# Altium schematic sheet 02_RNWF UART to Cloud Add on Board .SchDoc("02_RNWF UART to Cloud Add on Board ") (continued)

## Library data of the parts
C1 = CAP0279: Manufacturer 1: KEMET; Manufacturer Part Number 1: C0402C104K8PACTU; Description_: CAP CER 0.1uF 10V 10% X5R SMD 0402; Dev Tools Status: Production; Populated: YES; Temperature Coefficient: X5R; Tolerance: 10%; Type: Ceramic; Category: Capacitor; Component Kind: Standard; ComponentLink1Description: SVN Datasheet; ComponentLink1URL: https://chn-vm-apps.microchip.com/mchprepo/parts/Datasheets/KEM_C1002_X7R_SMD.pdf; ComponentLink2Description: Local Datasheet; ComponentLink3Description: Open Symbol; ComponentLink4Description: Open Footprint; ComponentLink5Description: Open Footprint 2; ComponentLink6Description: Open Footprint 3; ComponentLink7Description: Open Footprint 4; Database Table Name: Capacitor; Library Name: MCHP-CDB.DbLib; Manufacturer 2: Murata; Manufacturer 3: KEMET; Manufacturer Part Number 2: GRM155R61A104KA01D; Manufacturer Part Number 3: C0402C104K8PAC; MCHP-ID: CAP0279; Operating Temperature: -55C to +85C; Quantity Override: 1; Revision: 1; Status: MCL Design; Supplier 1: Digi-Key; Supplier 2: Digi-Key; Supplier 3: KEMET; Supplier Part Number 1: 399-3027-1-ND; Supplier Part Number 2: 490-1318-2-ND; Supplier Part Number 3: C0402C104K8PAC; Symbol: Capacitor NP; ComponentLink2URL: C:\ALTIUM_WORK\00001_MCHP-CDB\Datasheets\KEM_C1002_X7R_SMD.pdf; ComponentLink3URL: C:\ALTIUM_WORK\00001_MCHP-CDB\Library\LibraryRefs\Capacitor NP.SchLib; ComponentLink4URL: C:\ALTIUM_WORK\00001_MCHP-CDB\Library\Footprints\CAP_C_0402.PcbLib
C2 C6 = CAP0279: Manufacturer 1: KEMET; Manufacturer Part Number 1: C0402C104K8PACTU; Description_: CAP CER 0.1uF 10V 10% X5R SMD 0402; Dev Tools Status: Production; Populated: YES; Temperature Coeffcient: X5R; Tolerance: 10%; Type: Ceramic; Category: Capacitor; Component Kind: Standard; ComponentLink1Description: SVN Datasheet; ComponentLink1URL: https://chn-vm-apps.microchip.com/mchprepo/parts/Datasheets/KEM_C1002_X7R_SMD.pdf; ComponentLink2Description: Local Datasheet; ComponentLink3Description: Open Symbol; ComponentLink4Description: Open Footprint; ComponentLink5Description: Open Footprint 2; ComponentLink6Description: Open Footprint 3; ComponentLink7Description: Open Footprint 4; Database Table Name: Capacitor; Library Name: MCHP-CDB.DbLib; Manufacturer 2: Murata; Manufacturer 3: KEMET; Manufacturer Part Number 2: GRM155R61A104KA01D; Manufacturer Part Number 3: C0402C104K8PAC; MCHP-ID: CAP0279; Operating Temperature: -55C to +85C; Quantity Override: 1; Revision: 1; Status: MCL Design; Supplier 1: Digi-Key; Supplier 2: Digi-Key; Supplier 3: KEMET; Supplier Part Number 1: 399-3027-1-ND; Supplier Part Number 2: 490-1318-2-ND; Supplier Part Number 3: C0402C104K8PAC; Symbol: Capacitor NP; ComponentLink2URL: C:\ALTIUM_WORK\00001_MCHP-CDB\Datasheets\KEM_C1002_X7R_SMD.pdf; ComponentLink3URL: C:\ALTIUM_WORK\00001_MCHP-CDB\Library\LibraryRefs\Capacitor NP.SchLib; ComponentLink4URL: C:\ALTIUM_WORK\00001_MCHP-CDB\Library\Footprints\CAP_C_0402.PcbLib
C3 C12 = CAP1268: Capacitor; Manufacturer 1: TDK Corporation; Manufacturer Part Number 1: C2012X7R1A475M125AC; Description_: CAP CER 4.7uF 10V 20% X7R SMD 0805; Dev Tools Status: Production; Owner: Mark Loper; Populated: YES; Temperature Coeffcient: X7R; Tolerance: 20%; Type: Ceramic; Category: Capacitor; Component Kind: Standard; ComponentLink1Description: SVN Datasheet; ComponentLink1URL: https://chn-vm-apps.microchip.com/mchprepo/parts/Datasheets/mlcc_commercial_general_en.pdf; ComponentLink2Description: Local Datasheet; ComponentLink3Description: Open Symbol; ComponentLink4Description: Open Footprint; ComponentLink5Description: Open Footprint 2; ComponentLink6Description: Open Footprint 3; ComponentLink7Description: Open Footprint 4; Database Table Name: Capacitor; Library Name: MCHP-CDB.DbLib; MCHP-ID: CAP1268; Operating Temperature: -55C to +125C; Quantity Override: 1; Revision: 1; Status: MCL Design; Supplier 1: Digi-Key; Supplier Part Number 1: 445-1605-1-ND; Symbol: Capacitor NP; ComponentLink2URL: C:\ALTIUM_WORK\00001_MCHP-CDB\Datasheets\mlcc_commercial_general_en.pdf; ComponentLink3URL: C:\ALTIUM_WORK\00001_MCHP-CDB\Library\LibraryRefs\Capacitor NP.SchLib; ComponentLink4URL: C:\ALTIUM_WORK\00001_MCHP-CDB\Library\Footprints\CAP_C_0805.PcbLib
C4 C5 C9 C17 C18 = CAP0279: Manufacturer 1: KEMET; Manufacturer Part Number 1: C0402C104K8PACTU; Description_: CAP CER 0.1uF 10V 10% X5R SMD 0402; Dev Tools Status: Production; Populated: YES; Restricted Sourcing: No; Temperature Coefficient: X5R; Tolerance: 10%; Type: Ceramic; Category: Capacitor; Component Kind: Standard; ComponentLink1Description: SVN Datasheet; ComponentLink1URL: https://chn-vm-apps.microchip.com/mchprepo/parts/Datasheets/KEM_C1002_X7R_SMD.pdf; ComponentLink2Description: Local Datasheet; ComponentLink3Description: Open Symbol; ComponentLink4Description: Open Footprint; ComponentLink5Description: Open Footprint 2; ComponentLink6Description: Open Footprint 3; ComponentLink7Description: Open Footprint 4; Database Table Name: Capacitor; Library Name: MCHP-CDB.DbLib; Manufacturer 2: Murata; Manufacturer 3: KEMET; Manufacturer Part Number 2: GRM155R61A104KA01D; Manufacturer Part Number 3: C0402C104K8PAC; MCHP-ID: CAP0279; Operating Temperature: -55C to +85C; Quantity Override: 1; Revision: 1; Status: MCL Design; Supplier 1: Digi-Key; Supplier 2: Digi-Key; Supplier 3: KEMET; Supplier Part Number 1: 399-3027-1-ND; Supplier Part Number 2: 490-1318-2-ND; Supplier Part Number 3: C0402C104K8PAC; Symbol: Capacitor NP; ComponentLink2URL: C:\ALTIUM_WORK\00001_MCHP-CDB\Datasheets\KEM_C1002_X7R_SMD.pdf; ComponentLink3URL: C:\ALTIUM_WORK\00001_MCHP-CDB\Library\LibraryRefs\Capacitor NP.SchLib; ComponentLink4URL: C:\ALTIUM_WORK\00001_MCHP-CDB\Library\Footprints\CAP_C_0402.PcbLib
C7 = CAP0597: Manufacturer 1: TDK Corporation; Manufacturer Part Number 1: C1608X5R1C225K080AB; Description_: CAP CER 2.2uF 16V 10% X5R SMD 0603; Dev Tools Status: Production; Populated: YES; Temperature Coefficient: X5R; Tolerance: 10%; Type: Ceramic; Voltage: 16V; Category: Capacitor; Component Kind: Standard; ComponentLink1Description: SVN Datasheet; ComponentLink1URL: https://chn-vm-apps.microchip.com/mchprepo/parts/Datasheets/general_B11.pdf; ComponentLink2Description: Local Datasheet; ComponentLink3Description: Open Symbol; ComponentLink4Description: Open Footprint; ComponentLink5Description: Open Footprint 2; ComponentLink6Description: Open Footprint 3; ComponentLink7Description: Open Footprint 4; Database Table Name: Capacitor; Library Name: MCHP-CDB.DbLib; MCHP-ID: CAP0597; Operating Temperature: -55C to +85C; Package: 0603; Quantity Override: 1; Revision: 6; Status: MCL Design; Supplier 1: Digi-Key; Supplier Part Number 1: 445-5157-1-ND; Symbol: Capacitor NP; ComponentLink2URL: C:\ALTIUM_WORK\00001_MCHP-CDB\Datasheets\general_B11.pdf; ComponentLink3URL: C:\ALTIUM_WORK\00001_MCHP-CDB\Library\LibraryRefs\Capacitor NP.SchLib; ComponentLink4URL: C:\ALTIUM_WORK\00001_MCHP-CDB\Library\Footprints\CAP_C_0603.PcbLib
C8 = CAP0279: Manufacturer 1: KEMET; Manufacturer Part Number 1: C0402C104K8PACTU; Description_: CAP CER 0.1uF 10V 10% X5R SMD 0402; Dev Tools Status: Production; Temperature Coeffcient: X5R; Tolerance: 10%; Type: Ceramic; Category: Capacitor; Component Kind: Standard; ComponentLink1Description: SVN Datasheet; ComponentLink1URL: https://chn-vm-apps.microchip.com/mchprepo/parts/Datasheets/KEM_C1002_X7R_SMD.pdf; ComponentLink2Description: Local Datasheet; ComponentLink3Description: Open Symbol; ComponentLink4Description: Open Footprint; ComponentLink5Description: Open Footprint 2; ComponentLink6Description: Open Footprint 3; ComponentLink7Description: Open Footprint 4; Database Table Name: Capacitor; Library Name: MCHP-CDB.DbLib; Manufacturer 2: Murata; Manufacturer 3: KEMET; Manufacturer Part Number 2: GRM155R61A104KA01D; Manufacturer Part Number 3: C0402C104K8PAC; MCHP-ID: CAP0279; Operating Temperature: -55C to +85C; Quantity Override: 1; Revision: 1; Status: MCL Design; Supplier 1: Digi-Key; Supplier 2: Digi-Key; Supplier 3: KEMET; Supplier Part Number 1: 399-3027-1-ND; Supplier Part Number 2: 490-1318-2-ND; Supplier Part Number 3: C0402C104K8PAC; Symbol: Capacitor NP; ComponentLink2URL: C:\ALTIUM_WORK\00001_MCHP-CDB\Datasheets\KEM_C1002_X7R_SMD.pdf; ComponentLink3URL: C:\ALTIUM_WORK\00001_MCHP-CDB\Library\LibraryRefs\Capacitor NP.SchLib; ComponentLink4URL: C:\ALTIUM_WORK\00001_MCHP-CDB\Library\Footprints\CAP_C_0402.PcbLib
C10 = CAP0627: Manufacturer 1: TDK; Manufacturer Part Number 1: C2012X5R1C226K125AC; Description_: CAP CER 22uF 16V 10% X5R SMD 0805; Dev Tools Status: Production; Populated: YES; Temperature Coeffcient: X5R; Tolerance: 10%; Type: Ceramic; Category: Capacitor; Component Kind: Standard; ComponentLink1Description: SVN Datasheet; ComponentLink1URL: https://chn-vm-apps.microchip.com/mchprepo/parts/Datasheets/general_B11.pdf; ComponentLink2Description: Local Datasheet; ComponentLink3Description: Open Symbol; ComponentLink4Description: Open Footprint; ComponentLink5Description: Open Footprint 2; ComponentLink6Description: Open Footprint 3; ComponentLink7Description: Open Footprint 4; Database Table Name: Capacitor; Library Name: MCHP-CDB.DbLib; MCHP-ID: CAP0627; Operating Temperature: -55C to +85C; Quantity Override: 1; Revision: 1; Status: MCL Design; Supplier 1: Digi-Key; Supplier Part Number 1: 445-6797-2-ND; Symbol: Capacitor NP; ComponentLink2URL: C:\ALTIUM_WORK\00001_MCHP-CDB\Datasheets\general_B11.pdf; ComponentLink3URL: C:\ALTIUM_WORK\00001_MCHP-CDB\Library\LibraryRefs\Capacitor NP.SchLib; ComponentLink4URL: C:\ALTIUM_WORK\00001_MCHP-CDB\Library\Footprints\CAP_C_0805.PcbLib
C11 = CAP1201: Manufacturer 1: Murata; Manufacturer Part Number 1: GRM033R61A103KA01D; Description_: CAP CER 10000pF 10V 10% X5R SMD 0201; Dev Tools Status: Production; Owner: Tad Ahlvers; Populated: YES; Restricted Sourcing: No; Temperature Coefficient: X5R; Tolerance: 10%; Type: Ceramic; Category: Capacitor; Component Kind: Standard; ComponentLink1Description: SVN Datasheet; ComponentLink1URL: https://chn-vm-apps.microchip.com/mchprepo/parts/Datasheets/c02e.pdf; ComponentLink2Description: Local Datasheet; ComponentLink3Description: Open Symbol; ComponentLink4Description: Open Footprint; ComponentLink5Description: Open Footprint 2; ComponentLink6Description: Open Footprint 3; ComponentLink7Description: Open Footprint 4; Database Table Name: Capacitor; Library Name: MCHP-CDB.DbLib; MCHP-ID: CAP1201; Operating Temperature: -55C to +85C; Quantity Override: 1; Revision: 1; Status: MCL Design; Supplier 1: Digi-Key; Supplier Part Number 1: 490-3166-2-ND; Symbol: Capacitor NP; ComponentLink2URL: C:\ALTIUM_WORK\00001_MCHP-CDB\Datasheets\c02e.pdf; ComponentLink3URL: C:\ALTIUM_WORK\00001_MCHP-CDB\Library\LibraryRefs\Capacitor NP.SchLib; ComponentLink4URL: C:\ALTIUM_WORK\00001_MCHP-CDB\Library\Footprints\CAP_C_0201.PcbLib
C13 C14 = CAP1237: Capacitor; Manufacturer 1: Murata; Manufacturer Part Number 1: GRM1555C1H180GA01D; Description_: CAP CER 18pF 50V 2% NP0 SMD 0402; Dev Tools Status: Production; Owner: Tad Ahlvers; Populated: YES; Temperature Coeffcient: 30ppm/C; Tolerance: 5%; Type: Ceramic; Voltage: 50V; Category: Capacitor; Component Kind: Standard; ComponentLink1Description: SVN Datasheet; ComponentLink1URL: https://chn-vm-apps.microchip.com/mchprepo/parts/Datasheets/GRM1555C1H180GA01D#.pdf; ComponentLink2Description: Local Datasheet; ComponentLink3Description: Open Symbol; ComponentLink4Description: Open Footprint; ComponentLink5Description: Open Footprint 2; ComponentLink6Description: Open Footprint 3; ComponentLink7Description: Open Footprint 4; Database Table Name: Capacitor; Library Name: MCHP-CDB.DbLib; MCHP-ID: CAP1237; Operating Temperature: -55C to +125C; Package: 0402; Quantity Override: 1; Revision: 2; Status: MCL Design; Supplier 1: Digi-Key; Supplier Part Number 1: 490-6204-6-ND; Symbol: Capacitor NP; ComponentLink2URL: C:\ALTIUM_WORK\00001_MCHP-CDB\Datasheets\GRM1555C1H180GA01D#.pdf; ComponentLink3URL: C:\ALTIUM_WORK\00001_MCHP-CDB\Library\LibraryRefs\Capacitor NP.SchLib; ComponentLink4URL: C:\ALTIUM_WORK\00001_MCHP-CDB\Library\Footprints\CAP_C_0402.PcbLib
C15 C16 = CAP0279: Manufacturer 1: KEMET; Manufacturer Part Number 1: C0402C104K8PACTU; Description_: CAP CER 0.1uF 10V 10% X5R SMD 0402; Dev Tools Status: Production; Restricted Sourcing: No; Temperature Coefficient: X5R; Tolerance: 10%; Type: Ceramic; Category: Capacitor; Component Kind: Standard; ComponentLink1Description: SVN Datasheet; ComponentLink1URL: https://chn-vm-apps.microchip.com/mchprepo/parts/Datasheets/KEM_C1002_X7R_SMD.pdf; ComponentLink2Description: Local Datasheet; ComponentLink3Description: Open Symbol; ComponentLink4Description: Open Footprint; ComponentLink5Description: Open Footprint 2; ComponentLink6Description: Open Footprint 3; ComponentLink7Description: Open Footprint 4; Database Table Name: Capacitor; Library Name: MCHP-CDB.DbLib; Manufacturer 2: Murata; Manufacturer 3: KEMET; Manufacturer Part Number 2: GRM155R61A104KA01D; Manufacturer Part Number 3: C0402C104K8PAC; MCHP-ID: CAP0279; Operating Temperature: -55C to +85C; Package: 0402; Quantity Override: 1; Revision: 1; Status: MCL Design; Supplier 1: Digi-Key; Supplier 2: Digi-Key; Supplier 3: KEMET; Supplier Part Number 1: 399-3027-1-ND; Supplier Part Number 2: 490-1318-2-ND; Supplier Part Number 3: C0402C104K8PAC; Symbol: Capacitor NP; ComponentLink2URL: C:\ALTIUM_WORK\00001_MCHP-CDB\Datasheets\KEM_C1002_X7R_SMD.pdf; ComponentLink3URL: C:\ALTIUM_WORK\00001_MCHP-CDB\Library\LibraryRefs\Capacitor NP.SchLib; ComponentLink4URL: C:\ALTIUM_WORK\00001_MCHP-CDB\Library\Footprints\CAP_C_0402.PcbLib
C19 = CAP1684: Manufacturer 1: TDK Corporation; Manufacturer Part Number 1: C1005X5R1A475K050BC; Description_: CAP CER 4.7uF 10V 10% X5R SMD 0402; Dev Tools Status: Production; Owner: Jesus Aviles; Temperature Coeffcient: X5R; Tolerance: 10%; Type: Ceramic; Category: Capacitor; Component Kind: Standard; ComponentLink1Description: SVN Datasheet; ComponentLink2Description: Local Datasheet; ComponentLink3Description: Open Symbol; ComponentLink4Description: Open Footprint; ComponentLink5Description: Open Footprint 2; ComponentLink6Description: Open Footprint 3; ComponentLink7Description: Open Footprint 4; Database Table Name: Capacitor; Library Name: MCHP-CDB.DbLib; MCHP-ID: CAP1684; Operating Temperature: -55C to 85C; Quantity Override: 1; Revision: 1; Status: MCL Design; Supplier 1: Digi-Key; Supplier Part Number 1: 445-13820-1-ND; Symbol: Capacitor NP; ComponentLink3URL: C:\ALTIUM_WORK\00001_MCHP-CDB\Library\LibraryRefs\Capacitor NP.SchLib; ComponentLink4URL: C:\ALTIUM_WORK\00001_MCHP-CDB\Library\Footprints\CAP_C_0402.PcbLib
D1-D3 = DIODE1091: Manufacturer 1: NXP Semiconductors; Manufacturer Part Number 1: PESD5V0X1BL,315; Description_: DIO ZENER PESD5V0X1BL 5V SMD SOD-882; Dev Tools Status: Production; Owner: Jairuz Agang-ang; Reverse Current: 100nA; Category: Diode; Component Kind: Standard; ComponentLink1Description: SVN Datasheet; ComponentLink1URL: https://chn-vm-apps.microchip.com/mchprepo/parts/Datasheets/PESD5V0X1BL.pdf; ComponentLink2Description: Local Datasheet; ComponentLink3Description: Open Symbol; ComponentLink4Description: Open Footprint; ComponentLink5Description: Open Footprint 2; ComponentLink6Description: Open Footprint 3; ComponentLink7Description: Open Footprint 4; Database Table Name: Diode; Library Name: MCHP-CDB.DbLib; MCHP-ID: DIODE1091; Operating Temperature: -55C to 150C; Package: SOD-882; Quantity Override: 1; Revision: 1; Status: MCL Design; Supplier 1: Digi-Key; Supplier 2: Digi-Key; Supplier Part Number 1: 568-4674-1-ND; Supplier Part Number 2: 568-4674-2-ND; Symbol: Diode TVS Bidirectional; Type: TVS; ComponentLink2URL: C:\ALTIUM_WORK\00001_MCHP-CDB\Datasheets\PESD5V0X1BL.pdf; ComponentLink3URL: C:\ALTIUM_WORK\00001_MCHP-CDB\Library\LibraryRefs\Diode TVS Bidirectional.SchLib; ComponentLink4URL: C:\ALTIUM_WORK\00001_MCHP-CDB\Library\Footprints\SOD-882.PcbLib
D4 = DIODE1058: LED; Manufacturer 1: Lite-On; Manufacturer Part Number 1: LTST-C190EKT; Database Table Name: Diode; Description_: DIO LED RED 2V 30mA 2mcd Clear SMD 0603; Dev Tools Status: Production; Forward Current: 30mA; Owner: Tad Ahlvers; Populated: YES; Power: 100mW; Reverse Current: 100uA; Reverse Voltage: 5V; Type: LED Single; Category: Diode; Component Kind: Standard; ComponentLink1Description: SVN Datasheet; ComponentLink1URL: https://chn-vm-apps.microchip.com/mchprepo/parts/Datasheets/LTST-C190EKT.pdf; ComponentLink2Description: Local Datasheet; ComponentLink3Description: Open Symbol; ComponentLink4Description: Open Footprint; ComponentLink5Description: Open Footprint 2; ComponentLink6Description: Open Footprint 3; ComponentLink7Description: Open Footprint 4; Library Name: MCHP-CDB.DbLib; Manufacturer 2: ZhiDing; Manufacturer Part Number 2: AL-S0603SRC-50-08-2; MCHP-ID: DIODE1058; Operating Temperature: -55C to +85C; Package: 0603; Quantity Override: 1; Revision: 2; Status: MCL Design; Supplier 1: Digi-Key; Supplier 2: Raynen; Supplier Part Number 1: 160-1182-1-ND; Supplier Part Number 2: AL-S0603SRC-50-08-2; Symbol: LED_red; ComponentLink2URL: C:\ALTIUM_WORK\00001_MCHP-CDB\Datasheets\LTST-C190EKT.pdf; ComponentLink3URL: C:\ALTIUM_WORK\00001_MCHP-CDB\Library\LibraryRefs\LED_red.SchLib; ComponentLink4URL: C:\ALTIUM_WORK\00001_MCHP-CDB\Library\Footprints\LED_0603_RED.PcbLib
FB1 = IND1092: FERRITE 180R 1.5A SMD 0603; Manufacturer 1: Murata Electronics North America; Manufacturer Part Number 1: BLM21PG221SN1D; Database Table Name: Inductor; DCR: 0.045R; Description_: FERRITE 2A 220R SMD 0805; Dev Tools Status: Production; Owner: Stephen Humberd; Test Frequency: 100MHz; Category: Inductor; Component Kind: Standard; ComponentLink1Description: SVN Datasheet; ComponentLink1URL: https://chn-vm-apps.microchip.com/mchprepo/parts/Datasheets/L0110S0100BLM21P.pdf; ComponentLink2Description: Local Datasheet; ComponentLink3Description: Open Symbol; ComponentLink4Description: Open Footprint; ComponentLink5Description: Open Footprint 2; ComponentLink6Description: Open Footprint 3; ComponentLink7Description: Open Footprint 4; Library Name: MCHP-CDB.DbLib; MCHP-ID: IND1092; Operating Temperature: -55C to +125C; Package: 0805; Quantity Override: 1; Revision: B; Status: MCL Design; Supplier 1: Digi-Key; Supplier Part Number 1: 490-1054-1-ND; Symbol: Ferrite Bead; Type: Ferrite Bead; ComponentLink2URL: C:\ALTIUM_WORK\00001_MCHP-CDB\Datasheets\L0110S0100BLM21P.pdf; ComponentLink3URL: C:\ALTIUM_WORK\00001_MCHP-CDB\Library\LibraryRefs\Ferrite Bead.SchLib; ComponentLink4URL: C:\ALTIUM_WORK\00001_MCHP-CDB\Library\Footprints\IND_C_0805.PcbLib
FB2 FB3 = IND1735: Manufacturer 1: Murata Electronics; Manufacturer Part Number 1: BLM15AG121SN1D; DCR: 0.19R; Description_: FERRITE 120R @ 100MHz 0.55A 0.19R SMD 0402; Dev Tools Status: Design; Owner: Jojie Batislaon; Populated: YES; RMS Current: 0.55A; Test Frequency: 100MHz; Type: Ferrite Bead; Category: Inductor; Component Kind: Standard; ComponentLink1Description: SVN Datasheet; ComponentLink1URL: https://chn-vm-apps.microchip.com/mchprepo/parts/Datasheets/ENFA0018.pdf; ComponentLink2Description: Local Datasheet; ComponentLink3Description: Open Symbol; ComponentLink4Description: Open Footprint; ComponentLink5Description: Open Footprint 2; ComponentLink6Description: Open Footprint 3; ComponentLink7Description: Open Footprint 4; Database Table Name: Inductor; Description: Inductor; Library Name: MCHP-CDB.DbLib; MCHP-ID: IND1735; Operating Temperature: -55C to +125C; Package: 0402; Quantity Override: 1; Revision: 1; Status: MCL Design; Supplier 1: Digi-Key; Supplier Part Number 1: 490-1005-2-ND; Symbol: Inductor; ComponentLink2URL: C:\ALTIUM_WORK\00001_MCHP-CDB\Datasheets\ENFA0018.pdf; ComponentLink3URL: C:\ALTIUM_WORK\00001_MCHP-CDB\Library\LibraryRefs\Inductor.SchLib; ComponentLink4URL: C:\ALTIUM_WORK\00001_MCHP-CDB\Library\Footprints\IND_C_0402.PcbLib
J1 = CON2554: USB type C connector; Manufacturer 1: GCT; Manufacturer Part Number 1: USB4105-GF-A; Current: 5A; Description_: CONN USB2.0 TYPE-C Female SMD/TH R/A; Dev Tools Status: Design; Owner: Balaji Narayana; Populated: YES; Voltage: 20V; Assembly Type: SMD; Category: Connector; Component Kind: Standard; ComponentLink1Description: SVN Datasheet; ComponentLink1URL: https://chn-vm-apps.microchip.com/mchprepo/parts/Datasheets/USB4105-GF-A.pdf; ComponentLink2Description: Local Datasheet; ComponentLink3Description: Open Symbol; ComponentLink4Description: Open Footprint; ComponentLink5Description: Open Footprint 2; ComponentLink6Description: Open Footprint 3; ComponentLink7Description: Open Footprint 4; Database Table Name: Connector; Library Name: MCHP-CDB.DbLib; Manufacturer 2: Cvilux USA; Manufacturer Part Number 2: CU3216SASDLR010-NH; MCHP-ID: CON2554; Operating Temperature: -40C to 85C; Quantity Override: 1; Revision: 1; Status: MCL Design; Supplier 1: Digi-Key; Supplier 2: Digi-Key; Supplier Part Number 1: 2073-USB4105-GF-ATR-ND; Supplier Part Number 2: 2987-CU3216SASDLR010-NHTR-ND; Symbol: CON_USB-2.0_TYPE-C_RA; Type: USB; ComponentLink2URL: C:\ALTIUM_WORK\00001_MCHP-CDB\Datasheets\USB4105-GF-A.pdf; ComponentLink3URL: C:\ALTIUM_WORK\00001_MCHP-CDB\Library\LibraryRefs\CON_USB-2.0_TYPE-C_RA.SchLib; ComponentLink4URL: C:\ALTIUM_WORK\00001_MCHP-CDB\Library\Footprints\CON_USB-2.0_TYPE-C_USB4105_SMD.PcbLib
J2 = CON1388: Manufacturer 1: Sullins; Manufacturer Part Number 1: GRPB081VWVN-RC; Database Table Name: Connector; Description_: CON HDR-1.27 Male 1x8 Gold 3MH TH VERT; Dev Tools Status: Production; Owner: Mike Ragsdale; Restricted Sourcing: No; Category: Connector; Component Kind: Standard; ComponentLink1Description: SVN Datasheet; ComponentLink1URL: https://chn-vm-apps.microchip.com/mchprepo/parts/Datasheets/71_GRPB___1VWVN-RC__10957-C.pdf; ComponentLink2Description: Local Datasheet; ComponentLink3Description: Open Symbol; ComponentLink4Description: Open Footprint; ComponentLink5Description: Open Footprint 2; ComponentLink6Description: Open Footprint 3; ComponentLink7Description: Open Footprint 4; Description: Header 1x8; Library Name: MCHP-CDB.DbLib; MCHP-ID: CON1388; Quantity Override: 1; Revision: 1; Status: MCL Design; Supplier 1: Digi-Key; Supplier Part Number 1: S9014E-08-ND; Symbol: Header 1x8; Type: Connector Strip; ComponentLink2URL: C:\ALTIUM_WORK\00001_MCHP-CDB\Datasheets\71_GRPB___1VWVN-RC__10957-C.pdf; ComponentLink3URL: C:\ALTIUM_WORK\00001_MCHP-CDB\Library\LibraryRefs\Header 1x8.SchLib; ComponentLink4URL: C:\ALTIUM_WORK\00001_MCHP-CDB\Library\Footprints\HDR_M_1.27_1x8.PcbLib
J3 = CON0441: Manufacturer 1: Sullins; Manufacturer Part Number 1: PEC05DAAN; Current: 3A; Database Table Name: Connector; Description_: CON HDR-2.54 Male 2x5 Tin 5.84MH TH VERT; Dev Tools Status: Production; Owner: Mike Sullivan (Arena); Populated: YES; Restricted Sourcing: No; Category: Connector; Component Kind: Standard; ComponentLink1Description: SVN Datasheet; ComponentLink1URL: https://chn-vm-apps.microchip.com/mchprepo/parts/Datasheets/97_Page78-79_HEADER_.100_2.54mm_MALE_BREAKAWAY.pdf; ComponentLink2Description: Local Datasheet; ComponentLink3Description: Open Symbol; ComponentLink4Description: Open Footprint; ComponentLink5Description: Open Footprint 2; ComponentLink6Description: Open Footprint 3; ComponentLink7Description: Open Footprint 4; Library Name: MCHP-CDB.DbLib; Manufacturer 2: SHENZHEN JUNHAO ENGINEERING; Manufacturer Part Number 2: R-213-1011-0021-400; MCHP-ID: CON0441; Quantity Override: 1; Revision: 2; Status: MCL Design; Supplier 1: Digi-Key; Supplier 2: SHENZHEN JUNHAO ENGINEERING; Supplier Part Number 1: S2012E-05-ND; Supplier Part Number 2: R-213-1011-0021-400; Symbol: Header 2x5; Type: Header 2.54mm; ComponentLink2URL: C:\ALTIUM_WORK\00001_MCHP-CDB\Datasheets\97_Page78-79_HEADER_.100_2.54mm_MALE_BREAKAWAY.pdf; ComponentLink3URL: C:\ALTIUM_WORK\00001_MCHP-CDB\Library\LibraryRefs\Header 2x5.SchLib; ComponentLink4URL: C:\ALTIUM_WORK\00001_MCHP-CDB\Library\Footprints\HDR_M_2.54_2x5.PcbLib
J4 = CON1236: Manufacturer 1: Molex Inc; Manufacturer Part Number 1: 0901200122; Database Table Name: Connector; Description_: CON HDR-2.54 Male 1x2 Tin 6.75MH TH VERT; Dev Tools Status: Production; Owner: Jairuz Agang-ang; Restricted Sourcing: No; Value: HDR-2.54 Male 1x2; Category: Connector; Component Kind: Standard; ComponentLink1Description: SVN Datasheet; ComponentLink1URL: https://chn-vm-apps.microchip.com/mchprepo/parts/Datasheets/901200122_sd.pdf; ComponentLink2Description: Local Datasheet; ComponentLink3Description: Open Symbol; ComponentLink4Description: Open Footprint; ComponentLink5Description: Open Footprint 2; ComponentLink6Description: Open Footprint 3; ComponentLink7Description: Open Footprint 4; Library Name: MCHP-CDB.DbLib; Manufacturer 2: SHENZHEN JUNHAO ENGINEERING; Manufacturer Part Number 2: R-211-0211-0021-000; MCHP-ID: CON1236; Quantity Override: 1; Revision: 2; Status: MCL Design; Supplier 1: Digi-Key; Supplier 2: SHENZHEN JUNHAO ENGINEERING; Supplier Part Number 1: WM8072-ND; Supplier Part Number 2: R-211-0211-0021-000; Symbol: Header 1x2; Type: Header 2.54mm; ComponentLink2URL: C:\ALTIUM_WORK\00001_MCHP-CDB\Datasheets\901200122_sd.pdf; ComponentLink3URL: C:\ALTIUM_WORK\00001_MCHP-CDB\Library\LibraryRefs\Header 1x2.SchLib; ComponentLink4URL: C:\ALTIUM_WORK\00001_MCHP-CDB\Library\Footprints\HDR_M_2.54_1x2.PcbLib
J5 = CON1105: Manufacturer 1: FCI; Manufacturer Part Number 1: 68000-103HLF; Database Table Name: Connector; Description_: CON HDR-2.54 Male 1x3 Gold 5.84MH TH VERT; Dev Tools Status: Production; Owner: Bogdan P (M91398); Populated: YES; Value: HDR-2.54 Male 1x3; Category: Connector; Component Kind: Standard; ComponentLink1Description: SVN Datasheet; ComponentLink1URL: https://chn-vm-apps.microchip.com/mchprepo/parts/Datasheets/68000.pdf; ComponentLink2Description: Local Datasheet; ComponentLink3Description: Open Symbol; ComponentLink4Description: Open Footprint; ComponentLink5Description: Open Footprint 2; ComponentLink6Description: Open Footprint 3; ComponentLink7Description: Open Footprint 4; Library Name: MCHP-CDB.DbLib; MCHP-ID: CON1105; Quantity Override: 1; Revision: 0; Status: MCL Design; Supplier 1: Digi-Key; Supplier Part Number 1: 609-3461-ND; Symbol: Header 1x3; Type: Header 2.54mm; ComponentLink2URL: C:\ALTIUM_WORK\00001_MCHP-CDB\Datasheets\68000.pdf; ComponentLink3URL: C:\ALTIUM_WORK\00001_MCHP-CDB\Library\LibraryRefs\Header 1x3.SchLib; ComponentLink4URL: C:\ALTIUM_WORK\00001_MCHP-CDB\Library\Footprints\HDR_M_2.54_1x3.PcbLib
J6 = CON1236: Manufacturer 1: Molex Inc; Manufacturer Part Number 1: 0901200122; Database Table Name: Connector; Description_: CON HDR-2.54 Male 1x2 Tin 6.75MH TH VERT; Dev Tools Status: Production; Owner: Jairuz Agang-ang; Populated: YES; Restricted Sourcing: No; Category: Connector; Component Kind: Standard; ComponentLink1Description: SVN Datasheet; ComponentLink1URL: https://chn-vm-apps.microchip.com/mchprepo/parts/Datasheets/901200122_sd.pdf; ComponentLink2Description: Local Datasheet; ComponentLink3Description: Open Symbol; ComponentLink4Description: Open Footprint; ComponentLink5Description: Open Footprint 2; ComponentLink6Description: Open Footprint 3; ComponentLink7Description: Open Footprint 4; Library Name: MCHP-CDB.DbLib; Manufacturer 2: SHENZHEN JUNHAO ENGINEERING; Manufacturer Part Number 2: R-211-0211-0021-000; MCHP-ID: CON1236; Quantity Override: 1; Revision: 2; Status: MCL Design; Supplier 1: Digi-Key; Supplier 2: SHENZHEN JUNHAO ENGINEERING; Supplier Part Number 1: WM8072-ND; Supplier Part Number 2: R-211-0211-0021-000; Symbol: Header 1x2; Type: Header 2.54mm; ComponentLink2URL: C:\ALTIUM_WORK\00001_MCHP-CDB\Datasheets\901200122_sd.pdf; ComponentLink3URL: C:\ALTIUM_WORK\00001_MCHP-CDB\Library\LibraryRefs\Header 1x2.SchLib; ComponentLink4URL: C:\ALTIUM_WORK\00001_MCHP-CDB\Library\Footprints\HDR_M_2.54_1x2.PcbLib
J7 J8 = CON0104: Manufacturer 1: Nextron; Manufacturer Part Number 1: 211-081A7-0021-400; Database Table Name: Connector; Description_: CON HDR-2.54 Male 1x8 Gold 5.84MH TH VERT; Dev Tools Status: Production; Populated: YES; Category: Connector; Component Kind: Standard; ComponentLink1Description: SVN Datasheet; ComponentLink1URL: https://chn-vm-apps.microchip.com/mchprepo/parts/Datasheets/TSW-XXX-XX-XXX-X-XX-XXX-MKT.pdf; ComponentLink2Description: Local Datasheet; ComponentLink3Description: Open Symbol; ComponentLink4Description: Open Footprint; ComponentLink5Description: Open Footprint 2; ComponentLink6Description: Open Footprint 3; ComponentLink7Description: Open Footprint 4; Description: Header 1x8; Library Name: MCHP-CDB.DbLib; Manufacturer 2: Samtec; Manufacturer Part Number 2: TSW-108-07-L-S; MCHP-ID: CON0104; Quantity Override: 1; Revision: 1; Status: MCL Design; Supplier 1: Nextron; Supplier 2: Samtec; Supplier Part Number 1: 211-081A7-0021-400; Supplier Part Number 2: TSW-108-07-L-S; Symbol: Header 1x8; Type: Header 2.54mm; ComponentLink2URL: C:\ALTIUM_WORK\00001_MCHP-CDB\Datasheets\TSW-XXX-XX-XXX-X-XX-XXX-MKT.pdf; ComponentLink3URL: C:\ALTIUM_WORK\00001_MCHP-CDB\Library\LibraryRefs\Header 1x8.SchLib; ComponentLink4URL: C:\ALTIUM_WORK\00001_MCHP-CDB\Library\Footprints\HDR_M_2.54_1x8.PcbLib
J9 = CON1114: Manufacturer 1: FCI; Manufacturer Part Number 1: 68002-404HLF; Database Table Name: Connector; Description_: CON HDR-2.54 Male 1x4 Tin 5.84MH TH VERT; Dev Tools Status: Production; Owner: Bogdan P (M91398); Populated: YES; Category: Connector; Component Kind: Standard; ComponentLink1Description: SVN Datasheet; ComponentLink2Description: Local Datasheet; ComponentLink3Description: Open Symbol; ComponentLink4Description: Open Footprint; ComponentLink5Description: Open Footprint 2; ComponentLink6Description: Open Footprint 3; ComponentLink7Description: Open Footprint 4; Library Name: MCHP-CDB.DbLib; Manufacturer 2: Nextron; Manufacturer Part Number 2: R-211-0411-0021-000; MCHP-ID: CON1114; Quantity Override: 1; Revision: 0; Status: MCL Design; Supplier 1: Digi-Key; Supplier 2: Raynen; Supplier Part Number 1: 609-3305-ND; Supplier Part Number 2: CONN00270B01; Symbol: Header 1x4; Type: Header 2.54mm; ComponentLink3URL: C:\ALTIUM_WORK\00001_MCHP-CDB\Library\LibraryRefs\Header 1x4.SchLib; ComponentLink4URL: C:\ALTIUM_WORK\00001_MCHP-CDB\Library\Footprints\HDR_M_2.54_1x4.PcbLib
J10 = CON1208: Manufacturer 1: Samtec; Manufacturer Part Number 1: SLM-112-01-L-S; Database Table Name: Connector; Description_: CON STRIP-1.27 Female 1x12 Gold TH VERT; Dev Tools Status: Production; Owner: Randolph Sobrepena; Category: Connector; Component Kind: Standard; ComponentLink1Description: SVN Datasheet; ComponentLink1URL: https://chn-vm-apps.microchip.com/mchprepo/parts/Datasheets/SLM-1XX-01-X-X-MKT; ComponentLink2Description: Local Datasheet; ComponentLink3Description: Open Symbol; ComponentLink4Description: Open Footprint; ComponentLink5Description: Open Footprint 2; ComponentLink6Description: Open Footprint 3; ComponentLink7Description: Open Footprint 4; Library Name: MCHP-CDB.DbLib; MCHP-ID: CON1208; Quantity Override: 1; Revision: 0; Status: MCL Design; Supplier 1: Samtec; Supplier Part Number 1: SLM-112-01-L-S; Symbol: Header 1x12; Type: Header 1.27mm; ComponentLink2URL: C:\ALTIUM_WORK\00001_MCHP-CDB\Datasheets\SLM-1XX-01-X-X-MKT; ComponentLink3URL: C:\ALTIUM_WORK\00001_MCHP-CDB\Library\LibraryRefs\Header 1x12.SchLib; ComponentLink4URL: C:\ALTIUM_WORK\00001_MCHP-CDB\Library\Footprints\HDR_F_1.27_1x12_U_TH_V_SAM_SLM-112-01-L-S.PcbLib
J11 = Header 1x12: Manufacturer 1: Samtec; Manufacturer Part Number 1: SLM-112-01-L-S; Description_: CON STRIP-1.27 Female 1x12 Gold TH VERT; Dev Tools Status: Production; MCHP-ID: CON1208; Owner: Randolph Sobrepena; Category: Connector; Component Kind: Standard; ComponentLink1Description: SVN Datasheet; ComponentLink1URL: https://chn-vm-apps.microchip.com/mchprepo/parts/Datasheets/SLM-1XX-01-X-X-MKT; ComponentLink2Description: Local Datasheet; ComponentLink3Description: Open Symbol; ComponentLink4Description: Open Footprint; ComponentLink5Description: Open Footprint 2; ComponentLink6Description: Open Footprint 3; ComponentLink7Description: Open Footprint 4; Quantity Override: 1; Revision: 0; Status: MCL Design; Supplier 1: Samtec; Supplier Part Number 1: SLM-112-01-L-S; Symbol: Header 1x12; Type: Header 1.27mm; ComponentLink2URL: C:\ALTIUM_WORK\00001_MCHP-CDB\Datasheets\SLM-1XX-01-X-X-MKT; ComponentLink3URL: C:\ALTIUM_WORK\00001_MCHP-CDB\Library\LibraryRefs\Header 1x12.SchLib; ComponentLink4URL: C:\ALTIUM_WORK\00001_MCHP-CDB\Library\Footprints\HDR_F_1.27_1x12_U_TH_V_SAM_SLM-112-01-L-S.PcbLib; Library Name: PIC32_Wi-Fi_Curiosity_V2.3_Draft.SCHLIB
J12 J13 = CON1209: Manufacturer 1: Samtec; Manufacturer Part Number 1: SLM-103-01-L-S; Database Table Name: Connector; Description_: CON STRIP-1.27 Female 1x3 Gold TH VERT; Dev Tools Status: Production; Owner: Randolph Sobrepena; Category: Connector; Component Kind: Standard; ComponentLink1Description: SVN Datasheet; ComponentLink1URL: https://chn-vm-apps.microchip.com/mchprepo/parts/Datasheets/SLM-1XX-01-X-X-MKT; ComponentLink2Description: Local Datasheet; ComponentLink3Description: Open Symbol; ComponentLink4Description: Open Footprint; ComponentLink5Description: Open Footprint 2; ComponentLink6Description: Open Footprint 3; ComponentLink7Description: Open Footprint 4; Library Name: MCHP-CDB.DbLib; MCHP-ID: CON1209; Quantity Override: 1; Revision: 0; Status: MCL Design; Supplier 1: Samtec; Supplier Part Number 1: SLM-103-01-L-S; Symbol: Header 1x3; Type: Header 1.27mm; ComponentLink2URL: C:\ALTIUM_WORK\00001_MCHP-CDB\Datasheets\SLM-1XX-01-X-X-MKT; ComponentLink3URL: C:\ALTIUM_WORK\00001_MCHP-CDB\Library\LibraryRefs\Header 1x3.SchLib; ComponentLink4URL: C:\ALTIUM_WORK\00001_MCHP-CDB\Library\Footprints\HDR_F_1.27_1x3_U_TH_V_SAM_SLM-103-01-L-S.PcbLib
JP1 = HW1100: Manufacturer 1: TE Connectivity; Manufacturer Part Number 1: 881545-2; Description_: MECH HW JUMPER 2.54mm 1x2 Handle Gold; Dev Tools Status: Production; Populated: YES; Value: Shunt 2.54mm 1x2 Handle; Category: Hardware; ComponentLink1Description: SVN Datasheet; ComponentLink1URL: https://chn-vm-apps.microchip.com/mchprepo/parts/Datasheets/ENG_CD_881545_K3.pdf; ComponentLink2Description: Local Datasheet; ComponentLink3Description: Open Symbol; ComponentLink4Description: Open Footprint; ComponentLink5Description: Open Footprint 2; ComponentLink6Description: Open Footprint 3; ComponentLink7Description: Open Footprint 4; Database Table Name: Mechanical; Library Name: MCHP-CDB.DbLib; Manufacturer 2: WCON; Manufacturer Part Number 2: 3730-1301OS0B01; MCHP-ID: HW1100; Quantity Override: 1; Revision: 0; Status: MCL Design; Supplier 1: Digi-Key; Supplier 2: Raynen; Supplier Part Number 1: A26242-ND; Supplier Part Number 2: CONN00060D01M; Symbol: Jumper Mechanical; Type: Jumper; ComponentLink2URL: C:\ALTIUM_WORK\00001_MCHP-CDB\Datasheets\ENG_CD_881545_K3.pdf; ComponentLink3URL: C:\ALTIUM_WORK\00001_MCHP-CDB\Library\LibraryRefs\Jumper Mechanical.SchLib; ComponentLink4URL: C:\ALTIUM_WORK\00001_MCHP-CDB\Library\Footprints\Jumper_W_Handle_Mechanical.PcbLib
R1 = RSMT1300: Manufacturer 1: Yageo; Manufacturer Part Number 1: RC0603JR-070RP; Description_: RES TF 0R JUMPER 1/10W SMD 0603; Dev Tools Status: Production; Owner: Narasimha Murthy; Populated: YES; Voltage: 75V; Category: Resistor; Component Kind: Standard; ComponentLink1Description: SVN Datasheet; ComponentLink1URL: https://chn-vm-apps.microchip.com/mchprepo/parts/Datasheets/PYu-RC_51_RoHS_P_1.pdf; ComponentLink2Description: Local Datasheet; ComponentLink3Description: Open Symbol; ComponentLink4Description: Open Footprint; ComponentLink5Description: Open Footprint 2; ComponentLink6Description: Open Footprint 3; ComponentLink7Description: Open Footprint 4; Composition: TF; Database Table Name: Resistor; Description: Resistor; Library Name: MCHP-CDB.DbLib; MCHP-ID: RSMT1300; Operating Temperature: -55C to +155C; Quantity Override: 1; Revision: 1; Status: MCL Design; Supplier 1: Digi-Key; Supplier Part Number 1: YAG1307CT-ND; Symbol: Resistor; Type: RES SMD; ComponentLink2URL: C:\ALTIUM_WORK\00001_MCHP-CDB\Datasheets\PYu-RC_51_RoHS_P_1.pdf; ComponentLink3URL: C:\ALTIUM_WORK\00001_MCHP-CDB\Library\LibraryRefs\Resistor.SchLib; ComponentLink4URL: C:\ALTIUM_WORK\00001_MCHP-CDB\Library\Footprints\RES_C_0603.PcbLib
R2 = RSMT1300: Manufacturer 1: Yageo; Manufacturer Part Number 1: RC0603JR-070RP; Description_: RES TF 0R JUMPER 1/10W SMD 0603; Dev Tools Status: Production; Owner: Narasimha Murthy; Populated: DNP; Voltage: 75V; Category: Resistor; Component Kind: Standard; ComponentLink1Description: SVN Datasheet; ComponentLink1URL: https://chn-vm-apps.microchip.com/mchprepo/parts/Datasheets/PYu-RC_51_RoHS_P_1.pdf; ComponentLink2Description: Local Datasheet; ComponentLink3Description: Open Symbol; ComponentLink4Description: Open Footprint; ComponentLink5Description: Open Footprint 2; ComponentLink6Description: Open Footprint 3; ComponentLink7Description: Open Footprint 4; Composition: TF; Database Table Name: Resistor; Description: Resistor; Library Name: MCHP-CDB.DbLib; MCHP-ID: RSMT1300; Operating Temperature: -55C to +155C; Quantity Override: 1; Revision: 1; Status: MCL Design; Supplier 1: Digi-Key; Supplier Part Number 1: YAG1307CT-ND; Symbol: Resistor; Type: RES SMD; ComponentLink2URL: C:\ALTIUM_WORK\00001_MCHP-CDB\Datasheets\PYu-RC_51_RoHS_P_1.pdf; ComponentLink3URL: C:\ALTIUM_WORK\00001_MCHP-CDB\Library\LibraryRefs\Resistor.SchLib; ComponentLink4URL: C:\ALTIUM_WORK\00001_MCHP-CDB\Library\Footprints\RES_C_0603.PcbLib
R3 R13 R16 R18 R38 R41 R52 R54 R86 R87 = RSMT1040: Manufacturer 1: Yageo; Manufacturer Part Number 1: RC0402JR-070RL; Description_: RES TKF 0R 1/16W SMD 0402; Dev Tools Status: Production; Owner: Sompoch Loboonlert; Power: 1/16W; Category: Resistor; Component Kind: Standard; ComponentLink1Description: SVN Datasheet; ComponentLink1URL: https://chn-vm-apps.microchip.com/mchprepo/parts/Datasheets/PYu-RC0402_51_RoHS_L_6.pdf; ComponentLink2Description: Local Datasheet; ComponentLink3Description: Open Symbol; ComponentLink4Description: Open Footprint; ComponentLink5Description: Open Footprint 2; ComponentLink6Description: Open Footprint 3; ComponentLink7Description: Open Footprint 4; Composition: TKF; Database Table Name: Resistor; Description: Resistor; Library Name: MCHP-CDB.DbLib; Manufacturer 2: Stackpole Electronics Inc; Manufacturer Part Number 2: RMCF0402ZT0R00; MCHP-ID: RSMT1040; Operating Temperature: -55C to +155C; Quantity Override: 1; Revision: 3; Status: MCL Design; Supplier 1: Digi-Key; Supplier 2: Digi-Key; Supplier Part Number 1: 311-0.0JRCT-ND; Supplier Part Number 2: RMCF0402ZT0R00TR-ND; Symbol: Resistor; Type: RES SMD; ComponentLink2URL: C:\ALTIUM_WORK\00001_MCHP-CDB\Datasheets\PYu-RC0402_51_RoHS_L_6.pdf; ComponentLink3URL: C:\ALTIUM_WORK\00001_MCHP-CDB\Library\LibraryRefs\Resistor.SchLib; ComponentLink4URL: C:\ALTIUM_WORK\00001_MCHP-CDB\Library\Footprints\RES_C_0402.PcbLib
  R38 R41 R52 R54 R86 R87: Package: 0402
R4 R10-R12 R14 R15 R19 R33 R35 R37 R39 R40 R42 R43 R46 R48-R50 R55 R57 R59 R61 R65 R66 R68 R70 R84 = RSMT1040: Manufacturer 1: Yageo; Manufacturer Part Number 1: RC0402JR-070RL; Description_: RES TKF 0R 1/16W SMD 0402; Dev Tools Status: Production; Owner: Sompoch Loboonlert; Populated: YES; Power: 1/16W; Category: Resistor; Component Kind: Standard; ComponentLink1Description: SVN Datasheet; ComponentLink1URL: https://chn-vm-apps.microchip.com/mchprepo/parts/Datasheets/PYu-RC0402_51_RoHS_L_6.pdf; ComponentLink2Description: Local Datasheet; ComponentLink3Description: Open Symbol; ComponentLink4Description: Open Footprint; ComponentLink5Description: Open Footprint 2; ComponentLink6Description: Open Footprint 3; ComponentLink7Description: Open Footprint 4; Composition: TKF; Database Table Name: Resistor; Description: Resistor; Library Name: MCHP-CDB.DbLib; Manufacturer 2: Stackpole Electronics Inc; Manufacturer Part Number 2: RMCF0402ZT0R00; MCHP-ID: RSMT1040; Operating Temperature: -55C to +155C; Quantity Override: 1; Revision: 3; Status: MCL Design; Supplier 1: Digi-Key; Supplier 2: Digi-Key; Supplier Part Number 1: 311-0.0JRCT-ND; Supplier Part Number 2: RMCF0402ZT0R00TR-ND; Symbol: Resistor; Type: RES SMD; ComponentLink2URL: C:\ALTIUM_WORK\00001_MCHP-CDB\Datasheets\PYu-RC0402_51_RoHS_L_6.pdf; ComponentLink3URL: C:\ALTIUM_WORK\00001_MCHP-CDB\Library\LibraryRefs\Resistor.SchLib; ComponentLink4URL: C:\ALTIUM_WORK\00001_MCHP-CDB\Library\Footprints\RES_C_0402.PcbLib
  R33 R35 R37 R39 R40 R42 R43 R46 R48-R50 R55 R57 R59 R61 R65 R66 R68 R70 R84: Package: 0402
R5 R31 = RSMT0439: Manufacturer 1: Vishay; Manufacturer Part Number 1: CRCW040210K0JNED; Description_: RES TKF 10k 5% 1/16W SMD 0402; Dev Tools Status: Production; Populated: YES; Power: 1/16W; Temperature Coeffcient: 100ppm/C; Voltage: 50V; Category: Resistor; Component Kind: Standard; ComponentLink1Description: SVN Datasheet; ComponentLink1URL: https://chn-vm-apps.microchip.com/mchprepo/parts/Datasheets/PYu-RC0402_51_RoHS_L_6.pdf; ComponentLink2Description: Local Datasheet; ComponentLink3Description: Open Symbol; ComponentLink4Description: Open Footprint; ComponentLink5Description: Open Footprint 2; ComponentLink6Description: Open Footprint 3; ComponentLink7Description: Open Footprint 4; Composition: TKF; Database Table Name: Resistor; Description: Resistor; Library Name: MCHP-CDB.DbLib; Manufacturer 2: Yageo; Manufacturer Part Number 2: RC0402JR-0710KL; MCHP-ID: RSMT0439; Operating Temperature: -55C to +155C; Quantity Override: 1; Revision: 1; Status: MCL Design; Supplier 1: Digi-Key; Supplier 2: Digi-Key; Supplier Part Number 1: 541-10KJCT-ND; Supplier Part Number 2: 311-10KJRCT-ND; Symbol: Resistor; Type: RES SMD; ComponentLink2URL: C:\ALTIUM_WORK\00001_MCHP-CDB\Datasheets\PYu-RC0402_51_RoHS_L_6.pdf; ComponentLink3URL: C:\ALTIUM_WORK\00001_MCHP-CDB\Library\LibraryRefs\Resistor.SchLib; ComponentLink4URL: C:\ALTIUM_WORK\00001_MCHP-CDB\Library\Footprints\RES_C_0402.PcbLib
R6 R7 = RES1655: Manufacturer 1: Panasonic Electronic Components; Manufacturer Part Number 1: ERJ-2RKF5101X; Description_: RES TKF 5.1k 1% 1/10W SMD 0402; Dev Tools Status: Production; Owner: Catalin Modoran; Populated: YES; Power: 1/10W; Temperature Coefficient: 100ppm/C; Category: Resistor; Component Kind: Standard; ComponentLink1Description: SVN Datasheet; ComponentLink1URL: https://chn-vm-apps.microchip.com/mchprepo/parts/Datasheets/AOA0000C86.pdf; ComponentLink2Description: Local Datasheet; ComponentLink3Description: Open Symbol; ComponentLink4Description: Open Footprint; ComponentLink5Description: Open Footprint 2; ComponentLink6Description: Open Footprint 3; ComponentLink7Description: Open Footprint 4; Composition: TKF; Database Table Name: Resistor; Description: Resistor; Library Name: MCHP-CDB.DbLib; MCHP-ID: RES1655; Operating Temperature: -55C to +155C; Quantity Override: 1; Revision: 1; Status: MCL Design; Supplier 1: Digi-Key; Supplier Part Number 1: P5.10KLCT-ND; Symbol: Resistor; Type: RES SMD; ComponentLink2URL: C:\ALTIUM_WORK\00001_MCHP-CDB\Datasheets\AOA0000C86.pdf; ComponentLink3URL: C:\ALTIUM_WORK\00001_MCHP-CDB\Library\LibraryRefs\Resistor.SchLib; ComponentLink4URL: C:\ALTIUM_WORK\00001_MCHP-CDB\Library\Footprints\RES_C_0402.PcbLib
R8 = RSMT0493: Manufacturer 1: Panasonic; Manufacturer Part Number 1: ERJ-3EKF1473V; Description_: RES TKF 147k 1% 1/10W SMD 0603; Dev Tools Status: Production; Populated: YES; Power: 1/10W; Temperature Coeffcient: 100ppm/C; Voltage: 75V; Category: Resistor; Component Kind: Standard; ComponentLink1Description: SVN Datasheet; ComponentLink1URL: https://chn-vm-apps.microchip.com/mchprepo/parts/Datasheets/AOA0000CE2.pdf; ComponentLink2Description: Local Datasheet; ComponentLink3Description: Open Symbol; ComponentLink4Description: Open Footprint; ComponentLink5Description: Open Footprint 2; ComponentLink6Description: Open Footprint 3; ComponentLink7Description: Open Footprint 4; Composition: TKF; Database Table Name: Resistor; Description: Resistor; Library Name: MCHP-CDB.DbLib; MCHP-ID: RSMT0493; Operating Temperature: -55C to +155C; Quantity Override: 1; Revision: 1; Status: MCL Design; Supplier 1: Digi-Key; Supplier Part Number 1: P147KHTR-ND; Symbol: Resistor; Type: RES SMD; ComponentLink2URL: C:\ALTIUM_WORK\00001_MCHP-CDB\Datasheets\AOA0000CE2.pdf; ComponentLink3URL: C:\ALTIUM_WORK\00001_MCHP-CDB\Library\LibraryRefs\Resistor.SchLib; ComponentLink4URL: C:\ALTIUM_WORK\00001_MCHP-CDB\Library\Footprints\RES_C_0603.PcbLib
R9 = RES1424: Manufacturer 1: Panasonic; Manufacturer Part Number 1: ERJ-2RKF2003X; Description_: RES TKF 200k 1% 1/10W SMD 0402; Dev Tools Status: Production; Owner: Tad Ahlvers; Populated: YES; Power: 1/10W; Temperature Coefficient: 100ppm/C; Voltage: 50V; Category: Resistor; Component Kind: Standard; ComponentLink1Description: SVN Datasheet; ComponentLink1URL: https://chn-vm-apps.microchip.com/mchprepo/parts/Datasheets/AOA0000CE2.pdf; ComponentLink2Description: Local Datasheet; ComponentLink3Description: Open Symbol; ComponentLink4Description: Open Footprint; ComponentLink5Description: Open Footprint 2; ComponentLink6Description: Open Footprint 3; ComponentLink7Description: Open Footprint 4; Composition: TKF; Database Table Name: Resistor; Description: Resistor; Library Name: MCHP-CDB.DbLib; MCHP-ID: RES1424; Operating Temperature: -55C to +125C; Quantity Override: 1; Revision: 1; Status: MCL Design; Supplier 1: Digi-Key; Supplier Part Number 1: P200KLCT-ND; Symbol: RESISTOR; Type: RES SMD; ComponentLink2URL: C:\ALTIUM_WORK\00001_MCHP-CDB\Datasheets\AOA0000CE2.pdf; ComponentLink3URL: C:\ALTIUM_WORK\00001_MCHP-CDB\Library\LibraryRefs\RESISTOR.SchLib; ComponentLink4URL: C:\ALTIUM_WORK\00001_MCHP-CDB\Library\Footprints\RES_C_0402.PcbLib
R17 = RSMT0309: Manufacturer 1: Panasonic; Manufacturer Part Number 1: ERJ-3EKF2002V; Description_: RES TKF 20k 1% 1/10W SMD 0603; Dev Tools Status: Production; Populated: YES; Power: 1/10W; Temperature Coeffcient: 100ppm/C; Voltage: 50V; Category: Resistor; Component Kind: Standard; ComponentLink1Description: SVN Datasheet; ComponentLink1URL: https://chn-vm-apps.microchip.com/mchprepo/parts/Datasheets/PYu-RC0603_51_RoHS_L_4.pdf; ComponentLink2Description: Local Datasheet; ComponentLink3Description: Open Symbol; ComponentLink4Description: Open Footprint; ComponentLink5Description: Open Footprint 2; ComponentLink6Description: Open Footprint 3; ComponentLink7Description: Open Footprint 4; Composition: TKF; Database Table Name: Resistor; Description: Resistor; Library Name: MCHP-CDB.DbLib; Manufacturer 2: Yageo; Manufacturer 3: Yageo; Manufacturer 4: Stackpole Electronics Inc; Manufacturer 5: Yageo; Manufacturer Part Number 2: 9C06031A2002FKHFT; Manufacturer Part Number 3: RC0603FR-0720KL; Manufacturer Part Number 4: RMCF1/1620.0K1%TR; Manufacturer Part Number 5: 9C06031A2002FKPFT; MCHP-ID: RSMT0309; Operating Temperature: -55C to +155C; Quantity Override: 1; Revision: 1; Status: MCL Design; Supplier 1: Digi-Key; Supplier 2: Digi-Key; Supplier 3: Digi-Key; Supplier 4: Yageo; Supplier 5: Stackpole Electronics Inc; Supplier 6: Yageo; Supplier Part Number 1: P20.0KHCT-ND; Supplier Part Number 2: 311-20.0KHTR-ND; Supplier Part Number 3: 311-20.0KHRCT-ND; Supplier Part Number 4: RC0603FR-0720KL; Supplier Part Number 5: RMCF1/1620.0K1%TR; Supplier Part Number 6: 9C06031A2002FKPFT; Symbol: Resistor; Type: RES SMD; ComponentLink2URL: C:\ALTIUM_WORK\00001_MCHP-CDB\Datasheets\PYu-RC0603_51_RoHS_L_4.pdf; ComponentLink3URL: C:\ALTIUM_WORK\00001_MCHP-CDB\Library\LibraryRefs\Resistor.SchLib; ComponentLink4URL: C:\ALTIUM_WORK\00001_MCHP-CDB\Library\Footprints\RES_C_0603.PcbLib
R20 = RES1813: Manufacturer 1: Yageo; Manufacturer Part Number 1: RC0402FR-074K7L; Circuit Type: Isolated; Description_: RES TKF 4.7k 1% 1/16W SMD 0402; Dev Tools Status: Production; Owner: Mike Ragsdale; Populated: YES; Power: 1/16W; Temperature Coefficient: 100ppm/C; Category: Resistor; Component Kind: Standard; ComponentLink1Description: SVN Datasheet; ComponentLink1URL: https://chn-vm-apps.microchip.com/mchprepo/parts/Datasheets/PYu-RC_Group_51_RoHS_L_8.pdf; ComponentLink2Description: Local Datasheet; ComponentLink3Description: Open Symbol; ComponentLink4Description: Open Footprint; ComponentLink5Description: Open Footprint 2; ComponentLink6Description: Open Footprint 3; ComponentLink7Description: Open Footprint 4; Database Table Name: Resistor; Description: Resistor; Library Name: MCHP-CDB.DbLib; MCHP-ID: RES1813; Operating Temperature: -55C to +155C; Quantity Override: 1; Revision: 1; Status: MCL Design; Supplier 1: Digi-Key; Supplier 2: Digi-Key; Supplier Part Number 1: 311-4.7KLRTR-ND; Supplier Part Number 2: 311-4.7KLRCT-ND; Symbol: resistor; Type: RES SMD; ComponentLink2URL: C:\ALTIUM_WORK\00001_MCHP-CDB\Datasheets\PYu-RC_Group_51_RoHS_L_8.pdf; ComponentLink3URL: C:\ALTIUM_WORK\00001_MCHP-CDB\Library\LibraryRefs\resistor.SchLib; ComponentLink4URL: C:\ALTIUM_WORK\00001_MCHP-CDB\Library\Footprints\RES_C_0402.PcbLib
R21 = RSMT1100: Manufacturer 1: Yageo; Manufacturer Part Number 1: RC0402FR-07330RL; Circuit Type: Isolated; Description_: RES TKF 330R 1% 1/16W SMD 0402; Dev Tools Status: Production; Owner: Mike Ragsdale; Populated: YES; Power: 1/16W; Category: Resistor; Component Kind: Standard; ComponentLink1Description: SVN Datasheet; ComponentLink1URL: https://chn-vm-apps.microchip.com/mchprepo/parts/Datasheets/PYu-RC_Group_51_RoHS_L_8.pdf; ComponentLink2Description: Local Datasheet; ComponentLink3Description: Open Symbol; ComponentLink4Description: Open Footprint; ComponentLink5Description: Open Footprint 2; ComponentLink6Description: Open Footprint 3; ComponentLink7Description: Open Footprint 4; Database Table Name: Resistor; Description: Resistor; Library Name: MCHP-CDB.DbLib; Manufacturer 2: Yageo; Manufacturer Part Number 2: RC0402FR-07330RL; MCHP-ID: RSMT1100; Quantity Override: 1; Revision: A; Status: MCL Design; Supplier 1: Digi-Key; Supplier 2: Digi-Key; Supplier Part Number 1: 311-330LRTR-ND; Supplier Part Number 2: 311-330LRCT-ND; Symbol: resistor; Type: RES SMD; ComponentLink2URL: C:\ALTIUM_WORK\00001_MCHP-CDB\Datasheets\PYu-RC_Group_51_RoHS_L_8.pdf; ComponentLink3URL: C:\ALTIUM_WORK\00001_MCHP-CDB\Library\LibraryRefs\resistor.SchLib; ComponentLink4URL: C:\ALTIUM_WORK\00001_MCHP-CDB\Library\Footprints\RES_C_0402.PcbLib
R22 = RSMT0439: Manufacturer 1: Vishay; Manufacturer Part Number 1: CRCW040210K0JNED; Description_: RES TKF 10k 5% 1/16W SMD 0402; Dev Tools Status: Production; Populated: YES; Power: 1/16W; Temperature Coefficient: 100ppm/C; Voltage: 50V; Category: Resistor; Component Kind: Standard; ComponentLink1Description: SVN Datasheet; ComponentLink1URL: https://chn-vm-apps.microchip.com/mchprepo/parts/Datasheets/PYu-RC0402_51_RoHS_L_6.pdf; ComponentLink2Description: Local Datasheet; ComponentLink3Description: Open Symbol; ComponentLink4Description: Open Footprint; ComponentLink5Description: Open Footprint 2; ComponentLink6Description: Open Footprint 3; ComponentLink7Description: Open Footprint 4; Composition: TKF; Database Table Name: Resistor; Description: Resistor; Library Name: MCHP-CDB.DbLib; Manufacturer 2: Yageo; Manufacturer Part Number 2: RC0402JR-0710KL; MCHP-ID: RSMT0439; Operating Temperature: -55C to +155C; Quantity Override: 1; Revision: 1; Status: MCL Design; Supplier 1: Digi-Key; Supplier 2: Digi-Key; Supplier Part Number 1: 541-10KJCT-ND; Supplier Part Number 2: 311-10KJRCT-ND; Symbol: Resistor; Type: RES SMD; ComponentLink2URL: C:\ALTIUM_WORK\00001_MCHP-CDB\Datasheets\PYu-RC0402_51_RoHS_L_6.pdf; ComponentLink3URL: C:\ALTIUM_WORK\00001_MCHP-CDB\Library\LibraryRefs\Resistor.SchLib; ComponentLink4URL: C:\ALTIUM_WORK\00001_MCHP-CDB\Library\Footprints\RES_C_0402.PcbLib
R23 = RES2795: Manufacturer 1: Bourns Inc.; Manufacturer Part Number 1: CR0603-J/-000ELF; Description_: RES TKF 0 OHM 1/10W  0603; Dev Tools Status: Production; Owner: Cristina Salanti; Populated: YES; Power: 1/10W; Temperature Coefficient: 100ppm/C; Voltage: 75V; Category: Resistor; Component Kind: Standard; ComponentLink1Description: SVN Datasheet; ComponentLink1URL: https://chn-vm-apps.microchip.com/mchprepo/parts/Datasheets/cr.pdf; ComponentLink2Description: Local Datasheet; ComponentLink3Description: Open Symbol; ComponentLink4Description: Open Footprint; ComponentLink5Description: Open Footprint 2; ComponentLink6Description: Open Footprint 3; ComponentLink7Description: Open Footprint 4; Composition: TKF; Database Table Name: Resistor; Description: Resistor; Library Name: MCHP-CDB.DbLib; MCHP-ID: RES2795; Operating Temperature: -55°C ~ 155°C; Quantity Override: 1; Revision: 1; Status: MCL Design; Supplier 1: Digi-Key; Supplier Part Number 1: CR0603-J/-000ELFCT-ND; Symbol: Resistor; Type: RES SMD; ComponentLink2URL: C:\ALTIUM_WORK\00001_MCHP-CDB\Datasheets\cr.pdf; ComponentLink3URL: C:\ALTIUM_WORK\00001_MCHP-CDB\Library\LibraryRefs\Resistor.SchLib; ComponentLink4URL: C:\ALTIUM_WORK\00001_MCHP-CDB\Library\Footprints\RES_C_0603.PcbLib
R24 = RSMT1100: Manufacturer 1: Yageo; Manufacturer Part Number 1: RC0402FR-07330RL; Circuit Type: Isolated; Description_: RES TKF 330R 1% 1/16W SMD 0402; Dev Tools Status: Production; Owner: Mike Ragsdale; Populated: YES; Power: 1/16W; Tolerance: 1%; Category: Resistor; Component Kind: Standard; ComponentLink1Description: SVN Datasheet; ComponentLink1URL: https://chn-vm-apps.microchip.com/mchprepo/parts/Datasheets/PYu-RC_Group_51_RoHS_L_8.pdf; ComponentLink2Description: Local Datasheet; ComponentLink3Description: Open Symbol; ComponentLink4Description: Open Footprint; ComponentLink5Description: Open Footprint 2; ComponentLink6Description: Open Footprint 3; ComponentLink7Description: Open Footprint 4; Database Table Name: Resistor; Description: Resistor; Library Name: MCHP-CDB.DbLib; Manufacturer 2: Yageo; Manufacturer Part Number 2: RC0402FR-07330RL; MCHP-ID: RSMT1100; Package: 0402; Quantity Override: 1; Revision: A; Status: MCL Design; Supplier 1: Digi-Key; Supplier 2: Digi-Key; Supplier Part Number 1: 311-330LRTR-ND; Supplier Part Number 2: 311-330LRCT-ND; Symbol: resistor; Type: RES SMD; ComponentLink2URL: C:\ALTIUM_WORK\00001_MCHP-CDB\Datasheets\PYu-RC_Group_51_RoHS_L_8.pdf; ComponentLink3URL: C:\ALTIUM_WORK\00001_MCHP-CDB\Library\LibraryRefs\resistor.SchLib; ComponentLink4URL: C:\ALTIUM_WORK\00001_MCHP-CDB\Library\Footprints\RES_C_0402.PcbLib
